# Altium schematic sheet 05 SoM Connectors.SchDoc
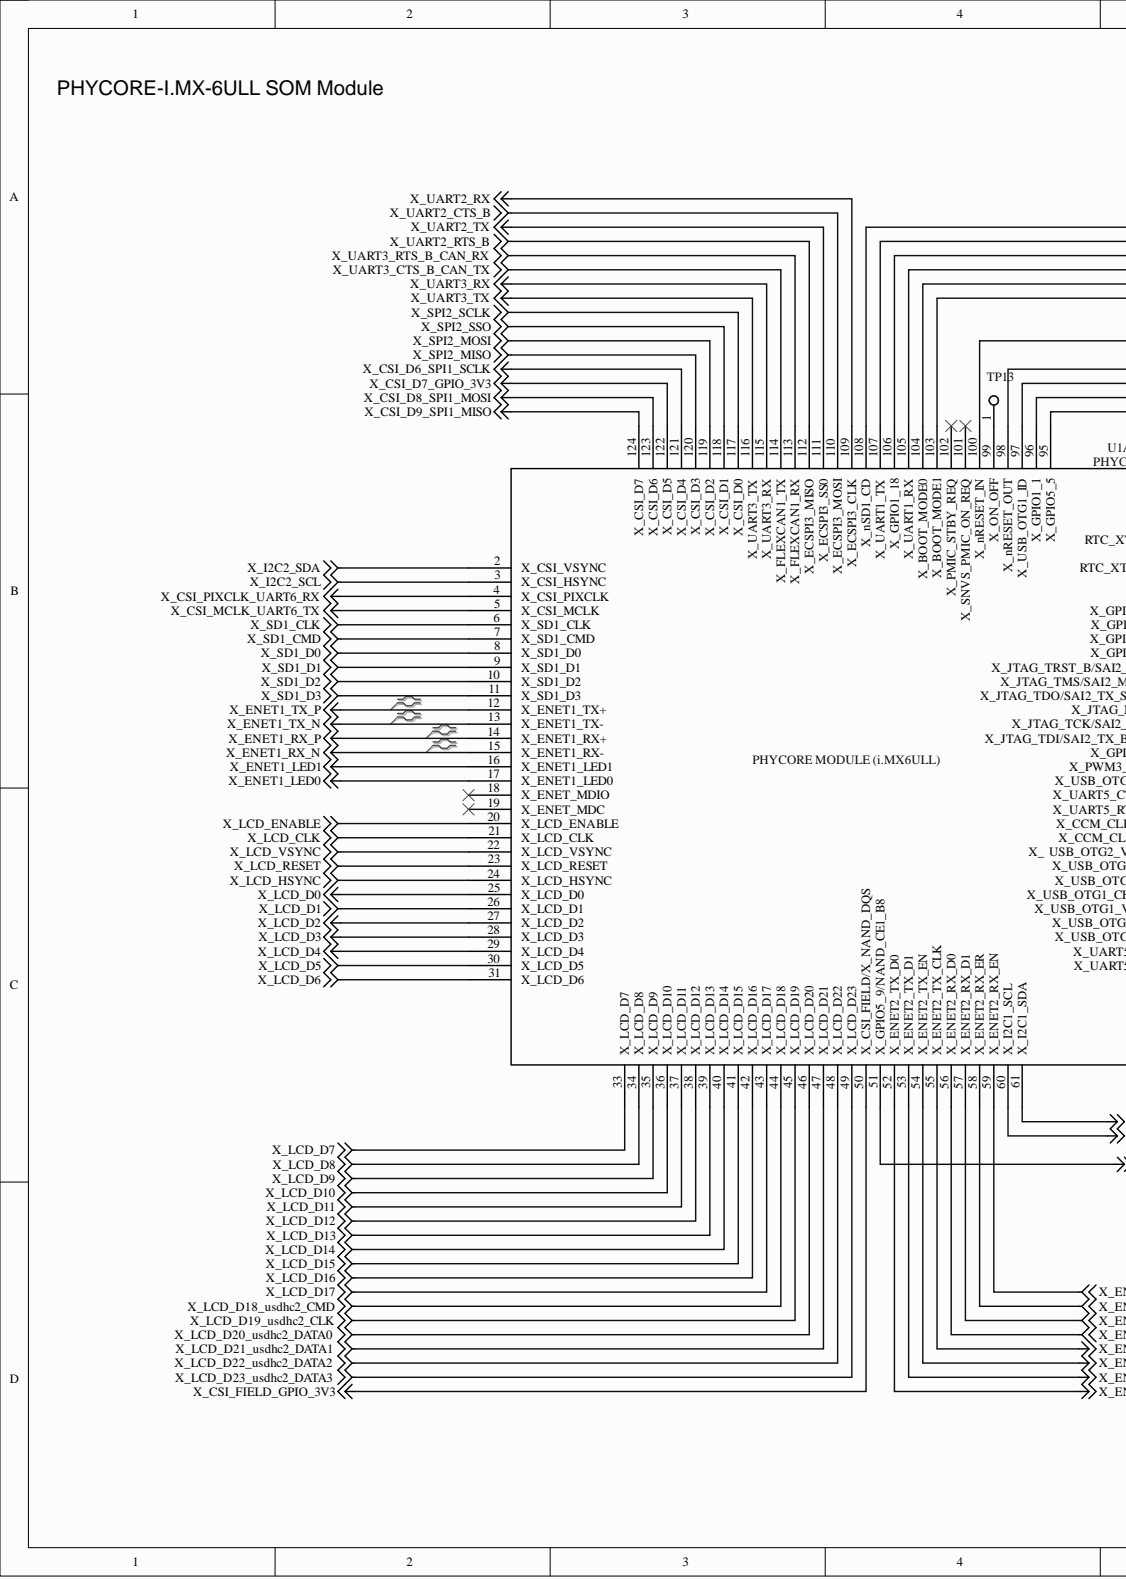
[diagram p0: 05 SoM Connectors.SchDoc, left]
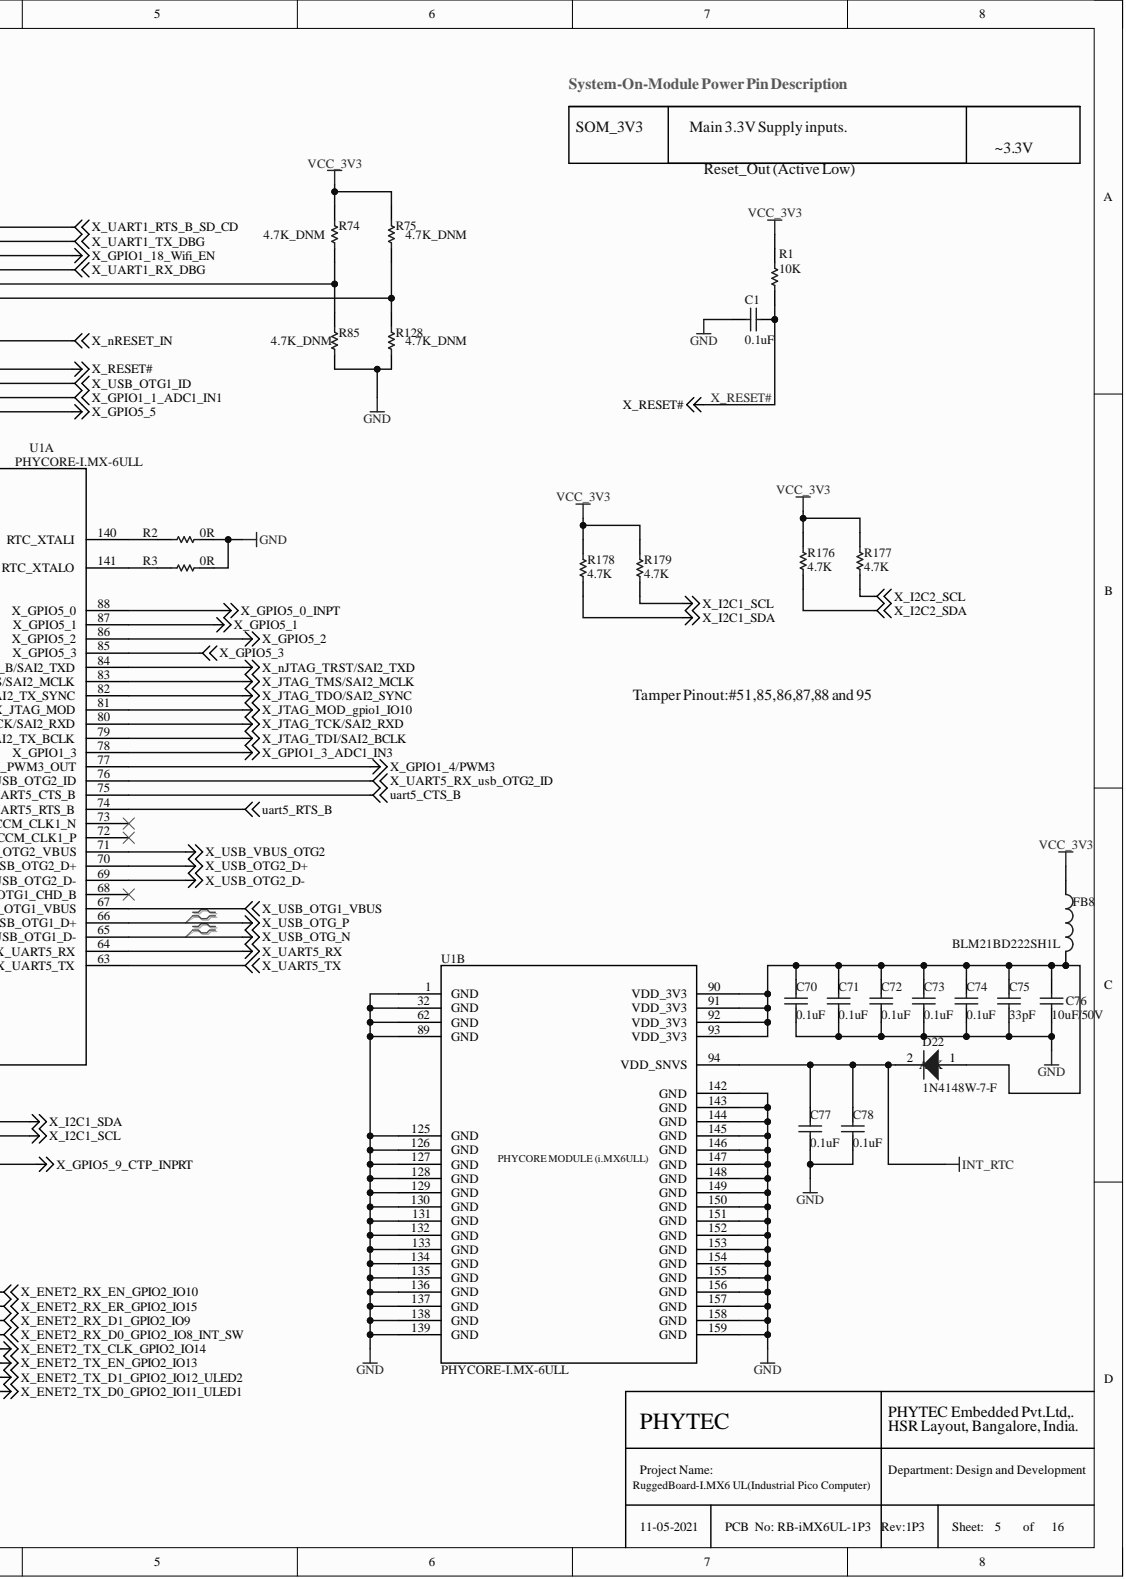
[diagram p1: 05 SoM Connectors.SchDoc, right]

## Parts
C1  0.1uF  [C0402]
C70  0.1uF  [C0402]
C71  0.1uF  [C0402]
C72  0.1uF  [C0402]
C73  0.1uF  [C0402]
C74  0.1uF  [C0402]
C75  33pF  [c0402]
C76  10uF/50V  [C1206]
C77  0.1uF  [C0402]
C78  0.1uF  [C0402]
D22  1N4148W-7-F  [SOD-123_1A_2K]
FB8  BLM21BD222SH1L  [L0805]
R1  10K  [R0402]
R2  0R  [R0402]
R3  0R  [R0402]
R74  4.7K_DNM  [R0402]
R75  4.7K_DNM  [R0402]
R85  4.7K_DNM  [R0402]
R128  4.7K_DNM  [R0402]
R176  4.7K  [R0402]
R177  4.7K  [R0402]
R178  4.7K  [R0402]
R179  4.7K  [R0402]
TP13  Test Point  [TEST_POINT_SMD_30D]  (value not drawn)
U1  PHYCORE-I.MX-6ULL  [phytec-PCL-063-Basis-35x35]  (parts A,B)

## Nets
GND: C1.2 C70.1 C71.1 C72.1 C73.1 C74.1 C75.1 C76.1 C77.1 C78.1 R2.1 R3.1 R85.1 R128.1 U1.1(GND) U1.32(GND) U1.62(GND) U1.89(GND) U1.125(GND) U1.126(GND) U1.127(GND) U1.128(GND) U1.129(GND) U1.130(GND) U1.131(GND) U1.132(GND) U1.133(GND) U1.134(GND) U1.135(GND) U1.136(GND) U1.137(GND) U1.138(GND) U1.139(GND) U1.142(GND) U1.143(GND) U1.144(GND) U1.145(GND) U1.146(GND) U1.147(GND) U1.148(GND) U1.149(GND) U1.150(GND) U1.151(GND) U1.152(GND) U1.153(GND) U1.154(GND) U1.155(GND) U1.156(GND) U1.157(GND) U1.158(GND) U1.159(GND)
INT_RTC: C77.2 C78.2 D22.2 U1.94(VDD_SNVS)
uart5_CTS_B: U1.75(X_UART5_CTS_B)
uart5_RTS_B: U1.74(X_UART5_RTS_B)
VCC_3V3: FB8.1 R1.1 R74.1 R75.2 R176.1 R177.2 R178.1 R179.2
X_CSI_D6_SPI1_SCLK: U1.121(X_CSI_D4)
X_CSI_D7_GPIO_3V3: U1.122(X_CSI_D5)
X_CSI_D8_SPI1_MOSI: U1.123(X_CSI_D6)
X_CSI_D9_SPI1_MISO: U1.124(X_CSI_D7)
X_CSI_FIELD_GPIO_3V3: U1.50(X_CSI_FIELD/X_NAND_DQS)
X_CSI_MCLK_UART6_TX: U1.5(X_CSI_MCLK)
X_CSI_PIXCLK_UART6_RX: U1.4(X_CSI_PIXCLK)
X_ENET1_LED0: U1.17(X_ENET1_LED0)
X_ENET1_LED1: U1.16(X_ENET1_LED1)
X_ENET1_RX_N: U1.15(X_ENET1_RX-)
X_ENET1_RX_P: U1.14(X_ENET1_RX+)
X_ENET1_TX_N: U1.13(X_ENET1_TX-)
X_ENET1_TX_P: U1.12(X_ENET1_TX+)
X_ENET2_RX_D0_GPIO2_IO8_INT_SW: U1.56(X_ENET2_RX_D0)
X_ENET2_RX_D1_GPIO2_IO9: U1.57(X_ENET2_RX_D1)
X_ENET2_RX_EN_GPIO2_IO10: U1.59(X_ENET2_RX_EN)
X_ENET2_RX_ER_GPIO2_IO15: U1.58(X_ENET2_RX_ER)
X_ENET2_TX_CLK_GPIO2_IO14: U1.55(X_ENET2_TX_CLK)
X_ENET2_TX_D0_GPIO2_IO11_ULED1: U1.52(X_ENET2_TX_D0)
X_ENET2_TX_D1_GPIO2_IO12_ULED2: U1.53(X_ENET2_TX_D1)
X_ENET2_TX_EN_GPIO2_IO13: U1.54(X_ENET2_TX_EN)
X_GPIO1_1_ADC1_IN1: U1.96(X_GPIO1_1)
X_GPIO1_3_ADC1_IN3: U1.78(X_GPIO1_3)
X_GPIO1_4/PWM3: U1.77(X_PWM3_OUT)
X_GPIO1_18_Wifi_EN: U1.106(X_GPIO1_18)
X_GPIO5_0_INPT: U1.88(X_GPIO5_0)
X_GPIO5_1: U1.87(X_GPIO5_1)
X_GPIO5_2: U1.86(X_GPIO5_2)
X_GPIO5_3: U1.85(X_GPIO5_3)
X_GPIO5_5: U1.95(X_GPIO5_5)
X_GPIO5_9_CTP_INPRT: U1.51(X_GPIO5_9/NAND_CE1_B8)
X_I2C1_SCL: R179.1 U1.60(X_I2C1_SCL)
X_I2C1_SDA: R178.2 U1.61(X_I2C1_SDA)
X_I2C2_SCL: R177.1 U1.3(X_CSI_HSYNC)
X_I2C2_SDA: R176.2 U1.2(X_CSI_VSYNC)
X_JTAG_MOD_gpio1_IO10: U1.81(X_JTAG_MOD)
X_JTAG_TCK/SAI2_RXD: U1.80(X_JTAG_TCK/SAI2_RXD)
X_JTAG_TDI/SAI2_BCLK: U1.79(X_JTAG_TDI/SAI2_TX_BCLK)
X_JTAG_TDO/SAI2_SYNC: U1.82(X_JTAG_TDO/SAI2_TX_SYNC)
X_JTAG_TMS/SAI2_MCLK: U1.83(X_JTAG_TMS/SAI2_MCLK)
X_LCD_CLK: U1.21(X_LCD_CLK)
X_LCD_D0: U1.25(X_LCD_D0)
X_LCD_D1: U1.26(X_LCD_D1)
X_LCD_D2: U1.27(X_LCD_D2)
X_LCD_D3: U1.28(X_LCD_D3)
X_LCD_D4: U1.29(X_LCD_D4)
X_LCD_D5: U1.30(X_LCD_D5)
X_LCD_D6: U1.31(X_LCD_D6)
X_LCD_D7: U1.33(X_LCD_D7)
X_LCD_D8: U1.34(X_LCD_D8)
X_LCD_D9: U1.35(X_LCD_D9)
X_LCD_D10: U1.36(X_LCD_D10)
X_LCD_D11: U1.37(X_LCD_D11)
X_LCD_D12: U1.38(X_LCD_D12)
X_LCD_D13: U1.39(X_LCD_D13)
X_LCD_D14: U1.40(X_LCD_D14)
X_LCD_D15: U1.41(X_LCD_D15)
X_LCD_D16: U1.42(X_LCD_D16)
X_LCD_D17: U1.43(X_LCD_D17)
X_LCD_D18_usdhc2_CMD: U1.44(X_LCD_D18)
X_LCD_D19_usdhc2_CLK: U1.45(X_LCD_D19)
X_LCD_D20_usdhc2_DATA0: U1.46(X_LCD_D20)
X_LCD_D21_usdhc2_DATA1: U1.47(X_LCD_D21)
X_LCD_D22_usdhc2_DATA2: U1.48(X_LCD_D22)
X_LCD_D23_usdhc2_DATA3: U1.49(X_LCD_D23)
X_LCD_ENABLE: U1.20(X_LCD_ENABLE)
X_LCD_HSYNC: U1.24(X_LCD_HSYNC)
X_LCD_RESET: U1.23(X_LCD_RESET)
X_LCD_VSYNC: U1.22(X_LCD_VSYNC)
X_nJTAG_TRST/SAI2_TXD: U1.84(X_JTAG_TRST_B/SAI2_TXD)
X_nRESET_IN: U1.100(X_nRESET_IN)
X_SD1_CLK: U1.6(X_SD1_CLK)
X_SD1_CMD: U1.7(X_SD1_CMD)
X_SD1_D0: U1.8(X_SD1_D0)
X_SD1_D1: U1.9(X_SD1_D1)
X_SD1_D2: U1.10(X_SD1_D2)
X_SD1_D3: U1.11(X_SD1_D3)
X_SPI2_MISO: U1.120(X_CSI_D3)
X_SPI2_MOSI: U1.119(X_CSI_D2)
X_SPI2_SCLK: U1.117(X_CSI_D0)
X_SPI2_SSO: U1.118(X_CSI_D1)
X_UART1_RTS_B_SD_CD: U1.108(X_nSD1_CD)
X_UART1_RX_DBG: U1.105(X_UART1_RX)
X_UART1_TX_DBG: U1.107(X_UART1_TX)
X_UART2_CTS_B: U1.110(X_ECSPI3_MOSI)
X_UART2_RTS_B: U1.112(X_ECSPI3_MISO)
X_UART2_RX: U1.109(X_ECSPI3_CLK)
X_UART2_TX: U1.111(X_ECSPI3_SS0)
X_UART3_CTS_B_CAN_TX: U1.114(X_FLEXCAN1_TX)
X_UART3_RTS_B_CAN_RX: U1.113(X_FLEXCAN1_RX)
X_UART3_RX: U1.115(X_UART3_RX)
X_UART3_TX: U1.116(X_UART3_TX)
X_UART5_RX: U1.64(X_UART5_RX)
X_UART5_RX_usb_OTG2_ID: U1.76(X_USB_OTG2_ID)
X_UART5_TX: U1.63(X_UART5_TX)
X_USB_OTG1_ID: U1.97(X_USB_OTG1_ID)
X_USB_OTG1_VBUS: U1.67(X_USB_OTG1_VBUS)
X_USB_OTG2_D+: U1.70(X_USB_OTG2_D+)
X_USB_OTG2_D-: U1.69(X_USB_OTG2_D-)
X_USB_OTG_N: U1.65(X_USB_OTG1_D-)
X_USB_OTG_P: U1.66(X_USB_OTG1_D+)
X_USB_VBUS_OTG2: U1.71(USB_OTG2_VBUS)
X_RESET#: C1.1 R1.2 U1.98(X_nRESET_OUT)
NetC70_2: C70.2 C71.2 C72.2 C73.2 C74.2 C75.2 C76.2 D22.1 FB8.2 U1.90(VDD_3V3) U1.91(VDD_3V3) U1.92(VDD_3V3) U1.93(VDD_3V3)
NetR2_2: R2.2 U1.140(RTC_XTALI)
NetR3_2: R3.2 U1.141(RTC_XTALO)
NetR74_2: R74.2 R85.2 U1.104(X_BOOT_MODE0)
NetR75_1: R75.1 R128.2 U1.103(X_BOOT_MODE1)
NetTP13_1: TP13.1 U1.99(X_ON_OFF)

Not connected: U1 18(X_ENET_MDIO) 19(X_ENET_MDC) 68(X_USB_OTG1_CHD_B) 72(X_CCM_CLK1_P) 73(X_CCM_CLK1_N) 101(X_SNVS_PMIC_ON_REQ) 102(X_PMIC_STBY_REQ)

## Library data of the parts
C1 = Cap: CAP CER 0.1UF 50V X7R 0402; ASSEMBLY_TYPE: SMT; MACRO: Power Management (VYS, 5V, 3.3V and Reset); MFG: Murata; MFG_PN: GRM155R71H104ME14D; Component Kind: Standard; Library Name: Miscellaneous Devices.IntLib; PACKAGE: 0402; Signal Integrity: Cap; Simulation: CAP; TEMPERATURE: -55°C ~ 125°C
C70-C74 C77 C78 = Cap: CAP CER 0.1UF 50V X7R 0402; ASSEMBLY_TYPE: SMT; MACRO: Power Management (VYS, 5V, 3.3V and Reset); MFG: Taiyo Yuden; MFG_PN: UMK105B7104KV-FR; Component Kind: Standard; Library Name: Miscellaneous Devices.IntLib; PACKAGE: 0402; Signal Integrity: Cap; Simulation: CAP; TEMPERATURE: -55°C ~ 125°C
C75 = Cap: CAP CER 33PF 50V C0G/NP0 0402; ASSEMBLY_TYPE: SMT; MFG: MURATA; MFG_PN: GRM1555C1H330JA01D; Component Kind: Standard; Library Name: Miscellaneous Devices.IntLib; PACKAGE: 0402; Signal Integrity: Cap; Simulation: CAP
C76 = Cap: CAP CER 10UF 50V X5R 1206; ASSEMBLY_TYPE: SMD; MFG: MURATA; MFG_PN: GRM31CR61H106KA12L; Component Kind: Standard; Library Name: Miscellaneous Devices.IntLib; PACKAGE: 1206; Signal Integrity: Cap; Simulation: CAP; TEMPERATURE: -55°C ~ 85°C
D22 = Diode: DIODE GEN PURP 100V 300MA SOD123; ASSEMBLY_TYPE: SMD; MFG: Diodes Incorporated; Component Kind: Standard; Library Name: Miscellaneous Devices.IntLib; MFG_PN: 1N4148W-7-F; PACKAGE: SOD123
FB8 = Inductor: FERRITE BEAD 2.25 KOHM 0805 1LN; ASSEMBLY_TYPE: SMD; MFG: MURATA; Component Kind: Standard; Library Name: Miscellaneous Devices.IntLib; MFG_PN: BLM21BD222SH1L; PACKAGE: 0805
R1 = Res1: RES SMD 10K OHM 1% 1/16W 0402; ASSEMBLY_TYPE: SMT; MFG: YAGEO; MFG_PN: RC0402FR-0710KL; Component Kind: Standard; Library Name: Miscellaneous Devices.IntLib; PACKAGE: 0402; Signal Integrity: Res; Simulation: RESISTOR
R2 R3 R85 R128 = Res1: RES SMD 0.0OHM JUMPER 1/16W 0402; ASSEMBLY_TYPE: SMT; MFG: YAGEO; MFG_PN: RC0402JR-070RL; Component Kind: Standard; Library Name: Miscellaneous Devices.IntLib; PACKAGE: 0402; Signal Integrity: Res; Simulation: RESISTOR
R74 R75 R176-R179 = Res1: RES SMD 4.7K OHM 1% 1/16W 0402; ASSEMBLY_TYPE: SMT; KHK_AN: RM938; MACRO: Ethernet PHY Transceiver; MFG: YAGEO; MFG_PN: RC0402FR-074K7L; Component Kind: Standard; Library Name: Miscellaneous Devices.IntLib; PACKAGE: 0402; Signal Integrity: Res; Simulation: RESISTOR; TEMPERATURE: -55°C ~ 155°C
TP13 = TP: TEST POINT PC MINI .040"D RED; ASSEMBLY_TYPE: SMD; Component Kind: Standard; PACKAGE: Assembly; Library Name: HTT SoM - Sept 7 2016 V1P2 .SCHLIB
U1 = Phycore_IMAX6_ULL: MFG: PHYTEC; Component Kind: Standard; Description: PHYCORE-I.MX-6ULL; MFG_PN: PHYCORE-I.MX-6ULL; Library Name: ADIO.SchLib
